# Altium schematic sheet Input.SchDoc(Input)
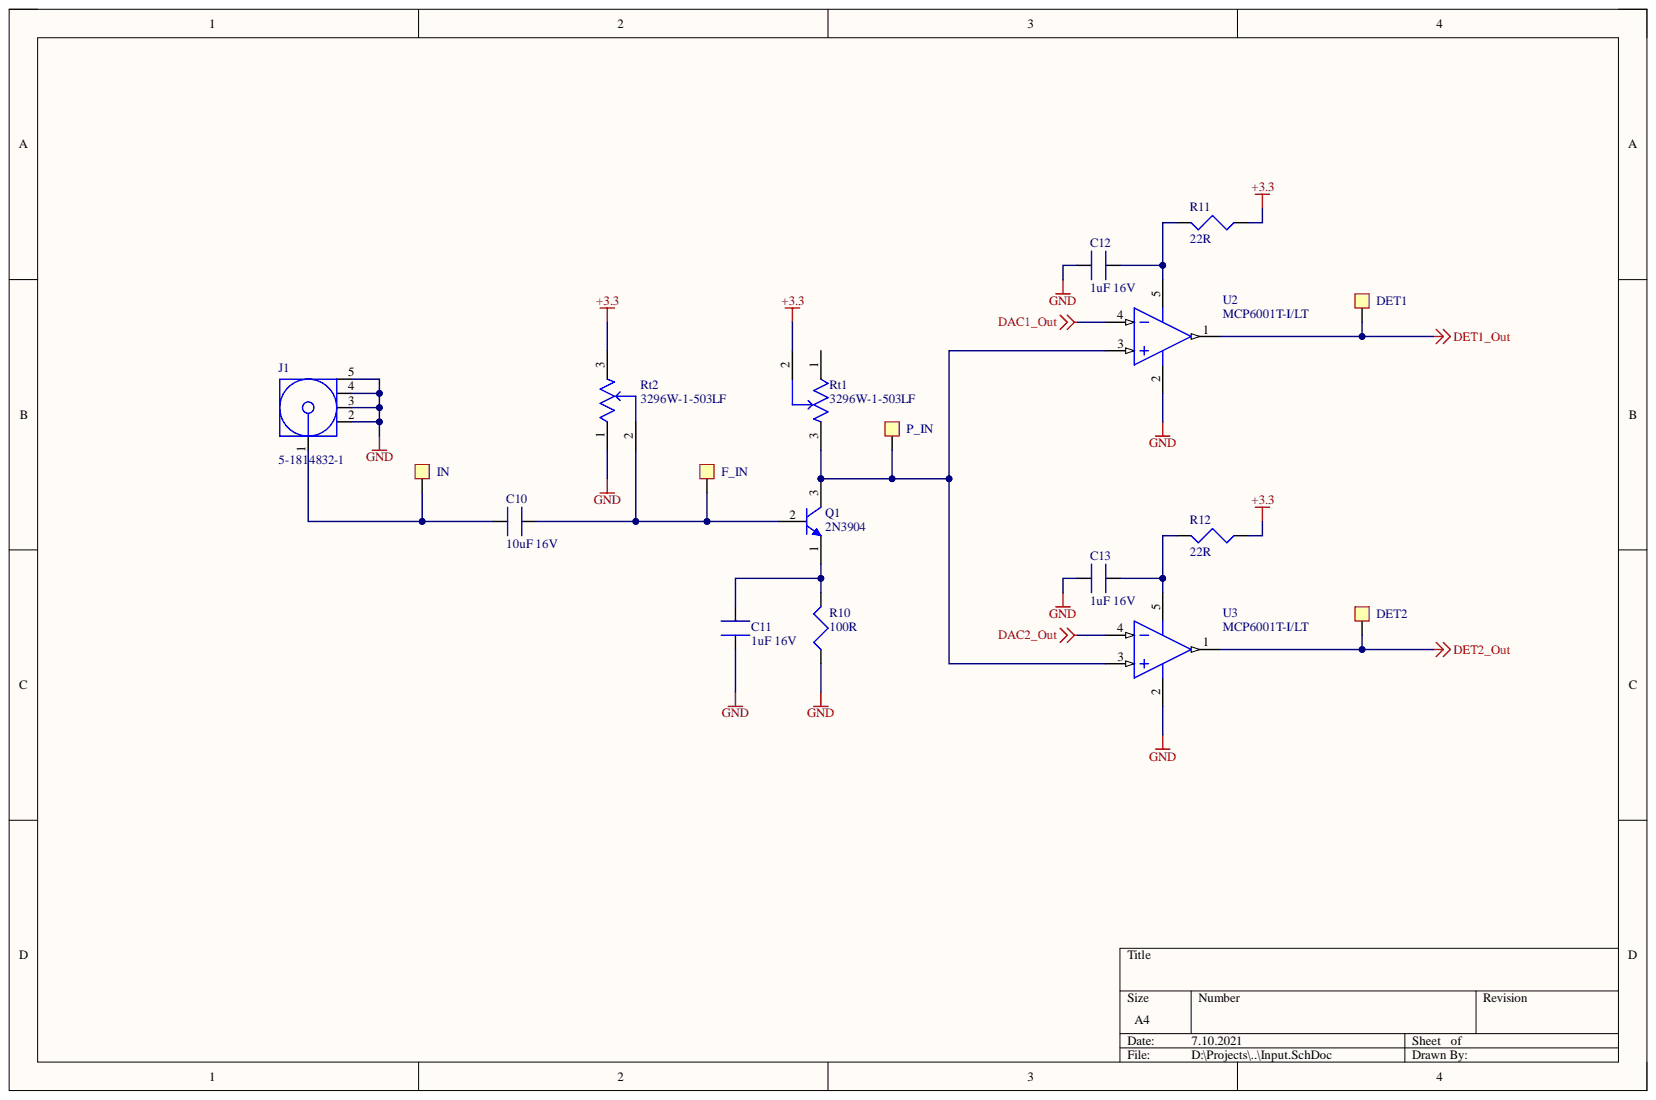
[diagram p0: Input.SchDoc]

## Parts
C10  10uF 16V  [0805_C]
C11  1uF 16V  [0805_C]
C12  1uF 16V  [0805_C]
C13  1uF 16V  [0805_C]
DET1  Point  [Test_Point]  (value not drawn)
DET2  Point  [Test_Point]  (value not drawn)
F_IN  Point  [Test_Point]  (value not drawn)
IN  Point  [Test_Point]  (value not drawn)
J1  5-1814832-1  [TECO-5-1814832-1_V]
P_IN  Point  [Test_Point]  (value not drawn)
Q1  2N3904  [ONSC-TO-92-3-29-11]
R10  100R  [0805_R]
R11  22R  [0805_R]
R12  22R  [0805_R]
Rt1  3296W-1-503LF  [FP-3296W-MFG]
Rt2  3296W-1-503LF  [FP-3296W-MFG]
U2  MCP6001T-I/LT  [SC70-LT5_M]
U3  MCP6001T-I/LT  [SC70-LT5_M]

## Nets
+3.3: R11.2 R12.2 Rt1.2 Rt2.3
DAC1_Out: U2.4
DAC2_Out: U3.4
DET1_Out: DET1.1 U2.1
DET2_Out: DET2.1 U3.1
GND: C11.1 C12.2 C13.2 J1.2 J1.3 J1.4 J1.5 R10.2 Rt2.1 U2.2 U3.2
NetC10_1: C10.1 IN.1 J1.1
NetC10_2: C10.2 F_IN.1 Q1.2 Rt2.2
NetC11_2: C11.2 Q1.1 R10.1
NetC12_1: C12.1 R11.1 U2.5
NetC13_1: C13.1 R12.1 U3.5
NetP_IN_1: P_IN.1 Q1.3 Rt1.3 U2.3 U3.3

Not connected: Rt1 1

## Library data of the parts
C10-C13 = Cap: Component Kind: Standard; Library Name: Schlib1.SchLib
J1 = CMP-2000-05415-1: SMA Connector, Pitch 5.08 mm, 1 Position, Height 9.5 mm, Tail Length 4 mm, -65 to 165 degC, RoHS, Bulk; Manufacturer: TE Connectivity; Coaxial Termination: Solder; Connector Body Style: StraightJack; Connector Mounting: PCBMount; Connector Type: SMACoaxial; Frequency Max: 3GHz; Impedance: 50ohm; Product Range: ZDCSeries; SVHC: NoSVHC(15-Jun-2015); Coaxial Cable Types: -; Component Kind: Standard; Contact Material: BerylliumCopper; Contact Plating: GoldPlatedContacts; Datasheet URL: http://www.onlinecomponents.com/productFiles/mf-AMP/eng_cd_1814832_a.pdf; Datasheet Version: Rev. B1, 03/2011; Library Name: Altium Content Vault; Manufacturer URL: http://www.te.com/; Package Description: SMA Connector, Pitch 5.08 mm, 1 Position, Height 9.5 mm, Tail Length 4 mm; Package Reference: 5-1814832-1
Q1 = CMP-2000-05562-1: General Purpose Transistor, NPN Silicon, 200 mA, 60 V, -55 to 150 degC, 3-Pin TO-92, RoHS, Bulk; Brand: ONSemiconductor; Collector- Base Voltage VCBO: 60V; Collector- Emitter Voltage VCEO Max: 40V; Collector-Emitter Saturation Voltage: 0.3V; Configuration: Single; DC Collector/Base Gain hfe Min: 60; Emitter- Base Voltage VEBO: 6V; Gain Bandwidth Product fT: 270MHz; Maximum DC Collector Current: 0.2A; Maximum Power Dissipation: 625mW; Component Kind: Standard; Datasheet URL: https://datasheet.ciiva.com/4725/2n3903-d-285841-4725808.pdf; Datasheet Version: Rev. 8, 08/2012; Factory Pack Quantity: 5000; Library Name: Altium Content Vault; Manufacturer: ONSemiconductor; Manufacturer URL: http://www.onsemi.com/; Maximum Operating Temperature: +150C; Minimum Operating Temperature: -65C; Mounting Style: ThroughHole; Mounting Technology: ThroughHole; Package / Case: TO-92-3(TO-226); Package Description: 3-Pin Transistor Outline, Body 4.825 x 3.685 mm, Pitch 1.27 mm; Package Reference: TO-92-3-29-11; Packaging: Bulk; Product Category: BipolarTransistors-BJT; RoHS: Details; Transistor Polarity: NPN
R10-R12 = Res: Component Kind: Standard; Library Name: Schlib1.SchLib
Rt1 Rt2 = CMP-2000-05583-2: TRIMMER 50K OHM 0.5W PC PIN TOP; Manufacturer: Bourns; Manufacturer Part Number: 3296W-1-504LF; Resistance: 500kΩ; Standoff Height: 0.38mm; Temperature Coefficient: 100ppm/°C; Tolerance: 10%; Value: 500kΩ; Voltage Rating: 300V; Automotive: No; Category: Res; Component Kind: Standard; ComponentLink2Description: Datasheet; ComponentLink2URL: https://www.bourns.com/docs/Product-Datasheets/3296.pdf; Device Class L1: Passive Components; Device Class L2: Resistors; Device Class L3: Variable Resistors and Potentiometers; Digikey Description: TRIMMER 500K OHM 0.5W PC PIN TOP; Height: 12.05mm; Lead Free: Yes; Library Name: Altium Content Vault; Mouser Description: Trimmer; Cermet; Rest 500 Kilohms; PCB; 25 Turns; Pwr-Rtg 0.5W; Octopart: https://octopart.com/3296w-1-504lf-bourns-143884; Package: 3296W; Power Rating: 0.5W; RoHS: TRUE; Series: Trimpot 3296-Sealed; Temperature: -55°C to +125°C; Temperature Range High: +125°C; Temperature Range Low: -55°C
U2 U3 = CMP-0187-00457-2: 1 MHz, Low-Power Operational Amplifier, 5-Pin SC-70, Industrial Temperature, Tape and Reel; Code_IPC: SOT353-5; Component Kind: Standard; ComponentLink1Description: Manufacturer URL; ComponentLink1URL: http://www.microchip.com/; ComponentLink2Description: Datasheet; ComponentLink2URL: http://ww1.microchip.com/downloads/en/DeviceDoc/21733j.pdf; ComponentLink3Description: Package Specification; ComponentLink3URL: http://www.microchip.com/stellent/groups/techpub_sg/documents/packagingspec/en012702.pdf; DatasheetVersion: revJ, Nov-2009; Library Name: Altium Content Vault; PackageDescription: 5-Lead Plastic Small Outline Transistor (LT) [SC70]; PackageReference: SC70-LT5; PackageVersion: revBB, Aug-2009; RevisionNote: URLs added.
DET1 DET2 F_IN IN P_IN = Point: Component Kind: Standard; Library Name: Schlib1.SchLib
